# Altium schematic sheet Master2.SchDoc
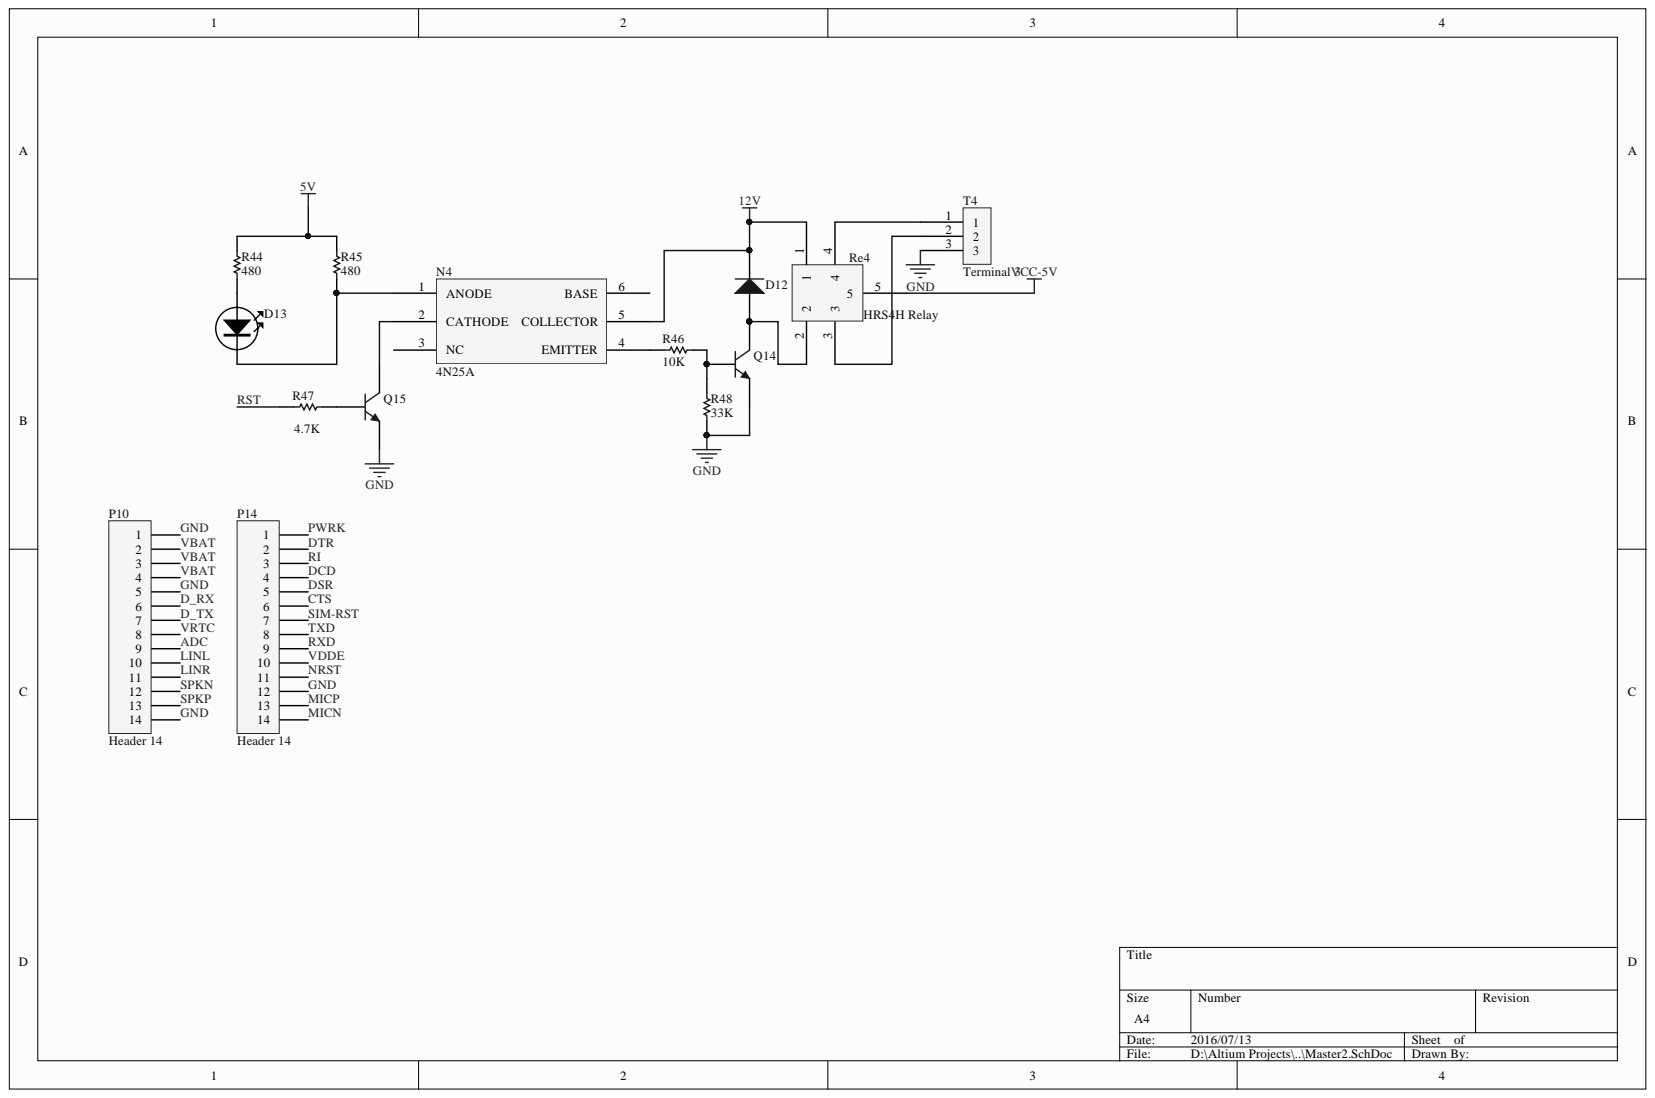
[diagram p0: Master2.SchDoc]

## Parts
D12  Diode 1N4007  [DO-41]  (value not drawn)
D13  LED-GREEN-3MM  [LED-3MM-GREEN]  (value not drawn)
N4  4N25A  [4N25A]
P10  Header 14  [HDR1X14]
P14  Header 14  [HDR1X14]
Q14  BC547  [SOT54]  (value not drawn)
Q15  BC547  [SOT54]  (value not drawn)
R44  value 480  [AXIAL-0.3]  (hidden comment: Res1)
R45  value 480  [AXIAL-0.3]  (hidden comment: Res1)
R46  value 10K  [AXIAL-0.3]  (hidden comment: Res1)
R47  value 4.7K  [AXIAL-0.3]  (hidden comment: Res1)
R48  value 33K  [AXIAL-0.3]  (hidden comment: Res1)
Re4  HRS4H Relay  [HRS4H-Relay]
T4  Terminal 3  [Terminal3]

## Nets
5V: R44.2 R45.2
12V: D12.2 N4.5(COLLECTOR) Re4.1
VCC-5V: Re4.5
ADC: P10.9
CTS: P14.6
D_RX: P10.6
D_TX: P10.7
DCD: P14.4
DSR: P14.5
DTR: P14.2
GND: P10.1 P10.5 P10.14 P14.12 Q14.1 Q15.1 R48.2 T4.3
LINL: P10.10
LINR: P10.11
MICN: P14.14
MICP: P14.13
NRST: P14.11
PWRK: P14.1
RI: P14.3
RST: R47.2
RXD: P14.9
SIM-RST: P14.7
SPKN: P10.12
SPKP: P10.13
TXD: P14.8
VBAT: P10.2 P10.3 P10.4
VDDE: P14.10
VRTC: P10.8
NetD12_1: D12.1 Q14.3 Re4.2
NetD13_A: D13.A R44.1
NetD13_K: D13.K N4.1(ANODE) R45.1
NetN4_2: N4.2(CATHODE) Q15.3
NetN4_4: N4.4(EMITTER) R46.2
NetQ14_2: Q14.2 R46.1 R48.1
NetQ15_2: Q15.2 R47.1
NetRe4_3: Re4.3 T4.2
NetRe4_4: Re4.4 T4.1

Not connected: N4 3(NC) 6(BASE)

## Library data of the parts
D12 = Diode 1N4007: 1 Amp General Purpose Rectifier; Code_JEDEC: DO-204-AL; Component Kind: Standard; ComponentLink1Description: Manufacturer Link; ComponentLink1URL: http://www.fairchildsemi.com/; ComponentLink2Description: Datasheet; ComponentLink2URL: http://www.fairchildsemi.com/ds/1N%2F1N4001.pdf; DatasheetVersion: 1998; LatestRevisionDate: 17-Jul-2002; LatestRevisionNote: Re-released for DXP Platform.; Library Name: Miscellaneous Devices.IntLib; PackageDescription: Diode, Axial; Body 5.2 x 2.7 mm (LxDia. max), Lead Dia. 0.86 mm (max); PackageReference: DO-41; PackageVersion: Aug-1999; Published: 8-Jun-2000; Signal Integrity: 1N4007; Simulation: 1N4007; Publisher: Altium Limited
P10 P14 = Header 14: Header, 14-Pin; Component Kind: Standard; LatestRevisionDate: 17-Jul-2002; LatestRevisionNote: Re-released for DXP Platform.; Library Name: Miscellaneous Connectors.IntLib; Signal Integrity: Connector; Publisher: Altium Limited
Q14 Q15 = BC547: NPN General-purpose Transistor; Code_JEDEC: TO-92; Code_JEITA: SC-43; Component Kind: Standard; ComponentLink1Description: Manufacturer Link; ComponentLink1URL: http://www.semiconductors.philips.com/; ComponentLink2Description: Datasheet; ComponentLink2URL: http://www.semiconductors.philips.com/acrobat/datasheets/BC546_547_3.pdf; DatasheetVersion: 15-Apr-1999; LatestRevisionDate: 12-Dec-2006; LatestRevisionNote: Footprint pad sequence fixed.; Library Name: Philips Discrete BJT - Low Power.IntLib; PackageDescription: Plastic Single-Ended Leaded (through hole) package; 3 Leads (TO-92); PackageReference: SOT54; PackageVersion: Feb-1997; Published: 17-Sep-2003; Signal Integrity: BC547; Publisher: Altium Limited
R44-R48 = Res1: Resistor; Component Kind: Standard; LatestRevisionDate: 17-Jul-2002; LatestRevisionNote: Re-released for DXP Platform.; Library Name: Miscellaneous Devices.IntLib; PackageDescription: Axial Device, Thru-Hole; 2 Leads; 0.3 in Pin Spacing; PackageReference: AXIAL-0.3; Published: 8-Jun-2000; Signal Integrity: Res; Simulation: RESISTOR; Publisher: Altium Limited
D13 = LED-GREEN-3MM: Component Kind: Standard; Description: LED-GREEN-3MM; Library Name: vh_altium_library.intLib
N4 = 4N25A: Component Kind: Standard; Description: 4N25A; Library Name: 4N25A.SchLib
Re4 = HRS4H Relay: Component Kind: Standard; Description: HRS4H Relay; Library Name: HRS4H-Relay.SchLib
T4 = Terminal 3: Component Kind: Standard; Library Name: Terminal3.SchLib
